# Altium schematic sheet PIXRACER-SD-USB-V1-R15.SchDoc(PIXRACER-SD-USB-V1-R15)
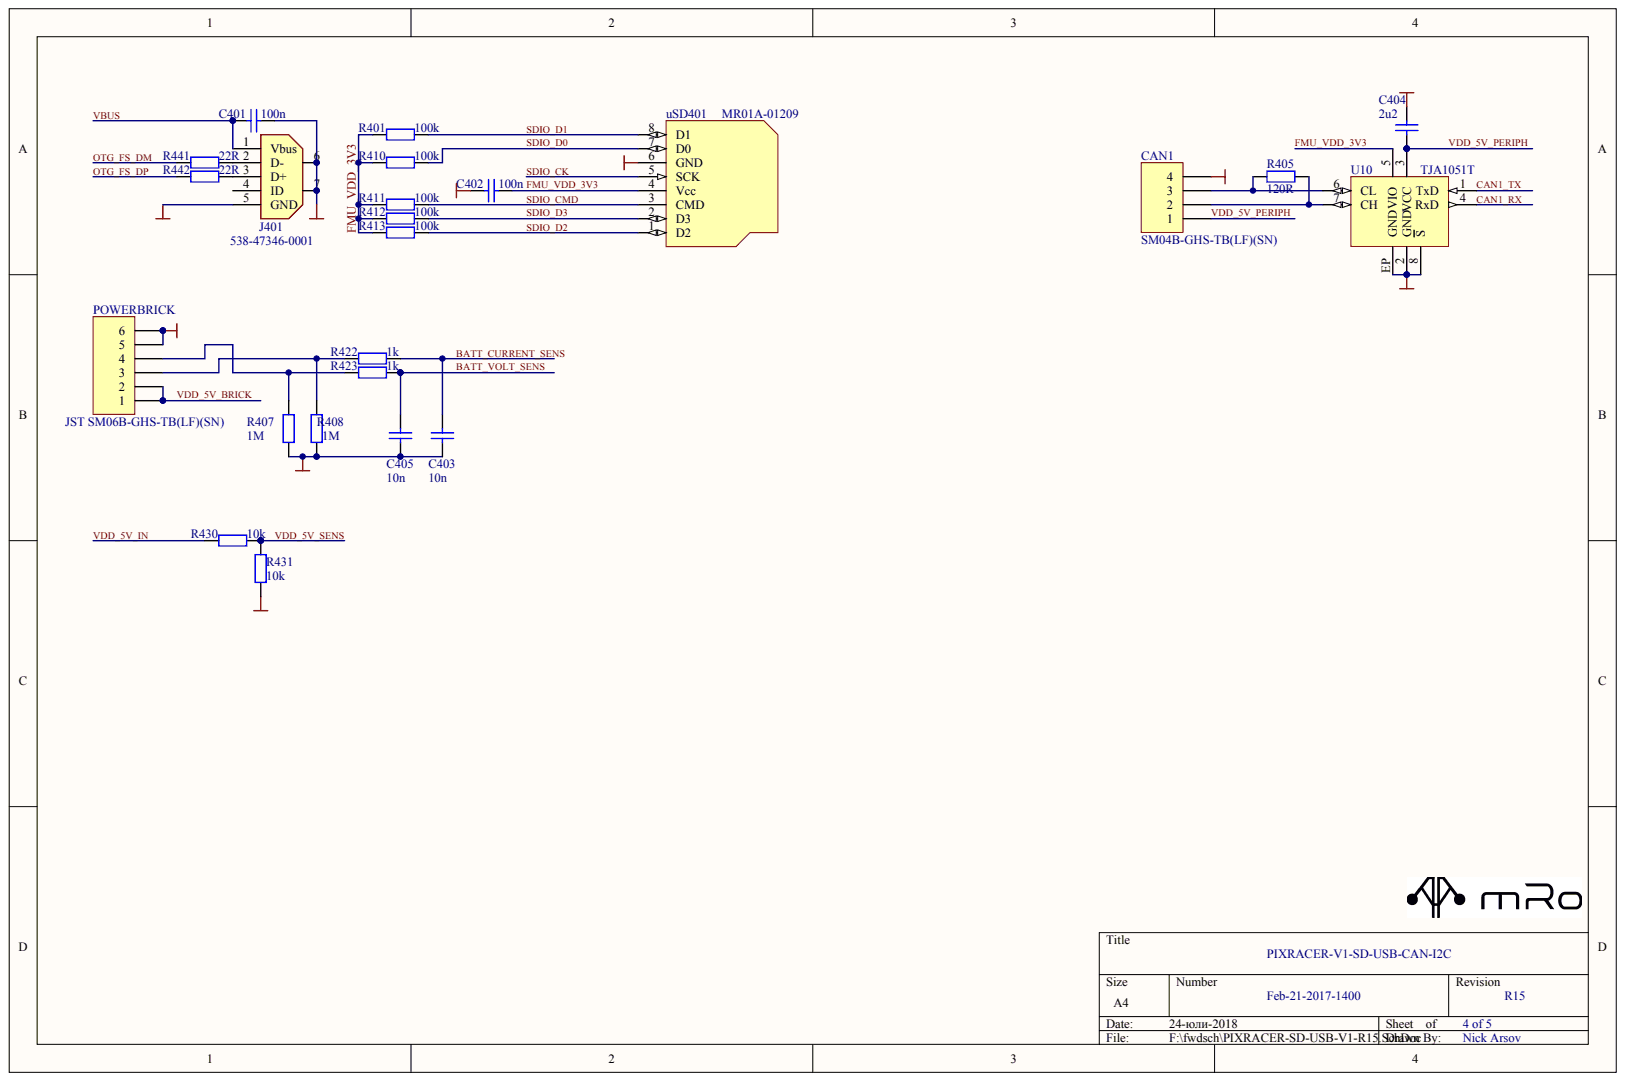
[diagram p0: PIXRACER-SD-USB-V1-R15.SchDoc]

## Parts
C401  100n
C402  100n
C403  10n
C404  2u2
C405  10n
CAN1  SM04B-GHS-TB(LF)(SN)
J401  Mouser Part Number: 538-47346-0001  (hidden comment: miniUSB)
POWERBRICK  JST SM06B-GHS-TB(LF)(SN)
R401  100k
R405  120R
R407  1M
R408  1M
R410  100k
R411  100k
R412  100k
R413  100k
R422  1k
R423  1k
R430  10k
R431  10k
R441  22R
R442  22R
U10  TJA1051T
uSD401  MR01A-01209

## Nets
GND: C401.1 C402.2 C403.2 C404.1 C405.2 CAN1.4 J401.5(GND) J401.6 J401.7 POWERBRICK.5 POWERBRICK.6 R407.2 R408.2 R431.2 U10.2(GND) U10.8(S) U10.EP(GND) uSD401.6(GND)
BATT_CURRENT_SENS: C403.1 R422.1
BATT_VOLT_SENS: C405.1 R423.1
CAN1_RX: U10.4(RxD)
CAN1_TX: U10.1(TxD)
FMU_VDD_3V3: C402.1 R401.2 R410.2 R411.2 R412.2 R413.2 U10.5(VIO) uSD401.4(Vcc)
OTG_FS_DM: R441.2
OTG_FS_DP: R442.2
SDIO_CK: uSD401.5(SCK)
SDIO_CMD: R411.1 uSD401.3(CMD)
SDIO_D0: R410.1 uSD401.7(D0)
SDIO_D1: R401.1 uSD401.8(D1)
SDIO_D2: R413.1 uSD401.1(D2)
SDIO_D3: R412.1 uSD401.2(D3)
VBUS: C401.2 J401.1(Vbus)
VDD_5V_BRICK: POWERBRICK.1 POWERBRICK.2
VDD_5V_IN: R430.2
VDD_5V_PERIPH: C404.2 CAN1.1 U10.3(VCC)
VDD_5V_SENS: R430.1 R431.1
NetCAN1_2: CAN1.2 R405.1 U10.7(CH)
NetCAN1_3: CAN1.3 R405.2 U10.6(CL)
NetJ401_2: J401.2(D-) R441.1
NetJ401_3: J401.3(D+) R442.1
NetPOWERBRICK_3: POWERBRICK.3 R408.1 R422.2
NetPOWERBRICK_4: POWERBRICK.4 R407.1 R423.2

Not connected: J401 4(ID)

## Library data of the parts
C401-C405 = (no symbol name): Value: 100pF; Published: 8-Jun-2000; LatestRevisionDate: 17-Jul-2002; LatestRevisionNote: Re-released for DXP Platform.; PackageReference: RAD-0.3; Publisher: Altium Limited
R401 R405 R407 R408 R410-R413 R430 R431 R441 R442 = (no symbol name): Value: 1K; Published: 8-Jun-2000; LatestRevisionDate: 17-Jul-2002; LatestRevisionNote: Re-released for DXP Platform.; PackageReference: AXIAL-0.4; Publisher: Altium Limited
  R401 R407 R408 R410-R413 R430 R431 R441 R442: PackageDescription: Axial Device, Thru-Hole; 2 Leads; 0.4 in Pin Spacing
CAN1 J401 POWERBRICK R422 R423 U10 uSD401 = (no symbol name)
  CAN1 POWERBRICK: LatestRevisionDate: 17-Jul-2002; LatestRevisionNote: Re-released for DXP Platform.
  R422 R423: Published: 8-Jun-2000; LatestRevisionDate: 17-Jul-2002; LatestRevisionNote: Re-released for DXP Platform.; PackageReference: AXIAL-0.4; PackageDescription: Axial Device, Thru-Hole; 2 Leads; 0.4 in Pin Spacing; Value: 1K
  uSD401: REM: MR01A-01209
  CAN1 POWERBRICK R422 R423: Publisher: Altium Limited
